# Altium schematic sheet power.SchDoc(power)
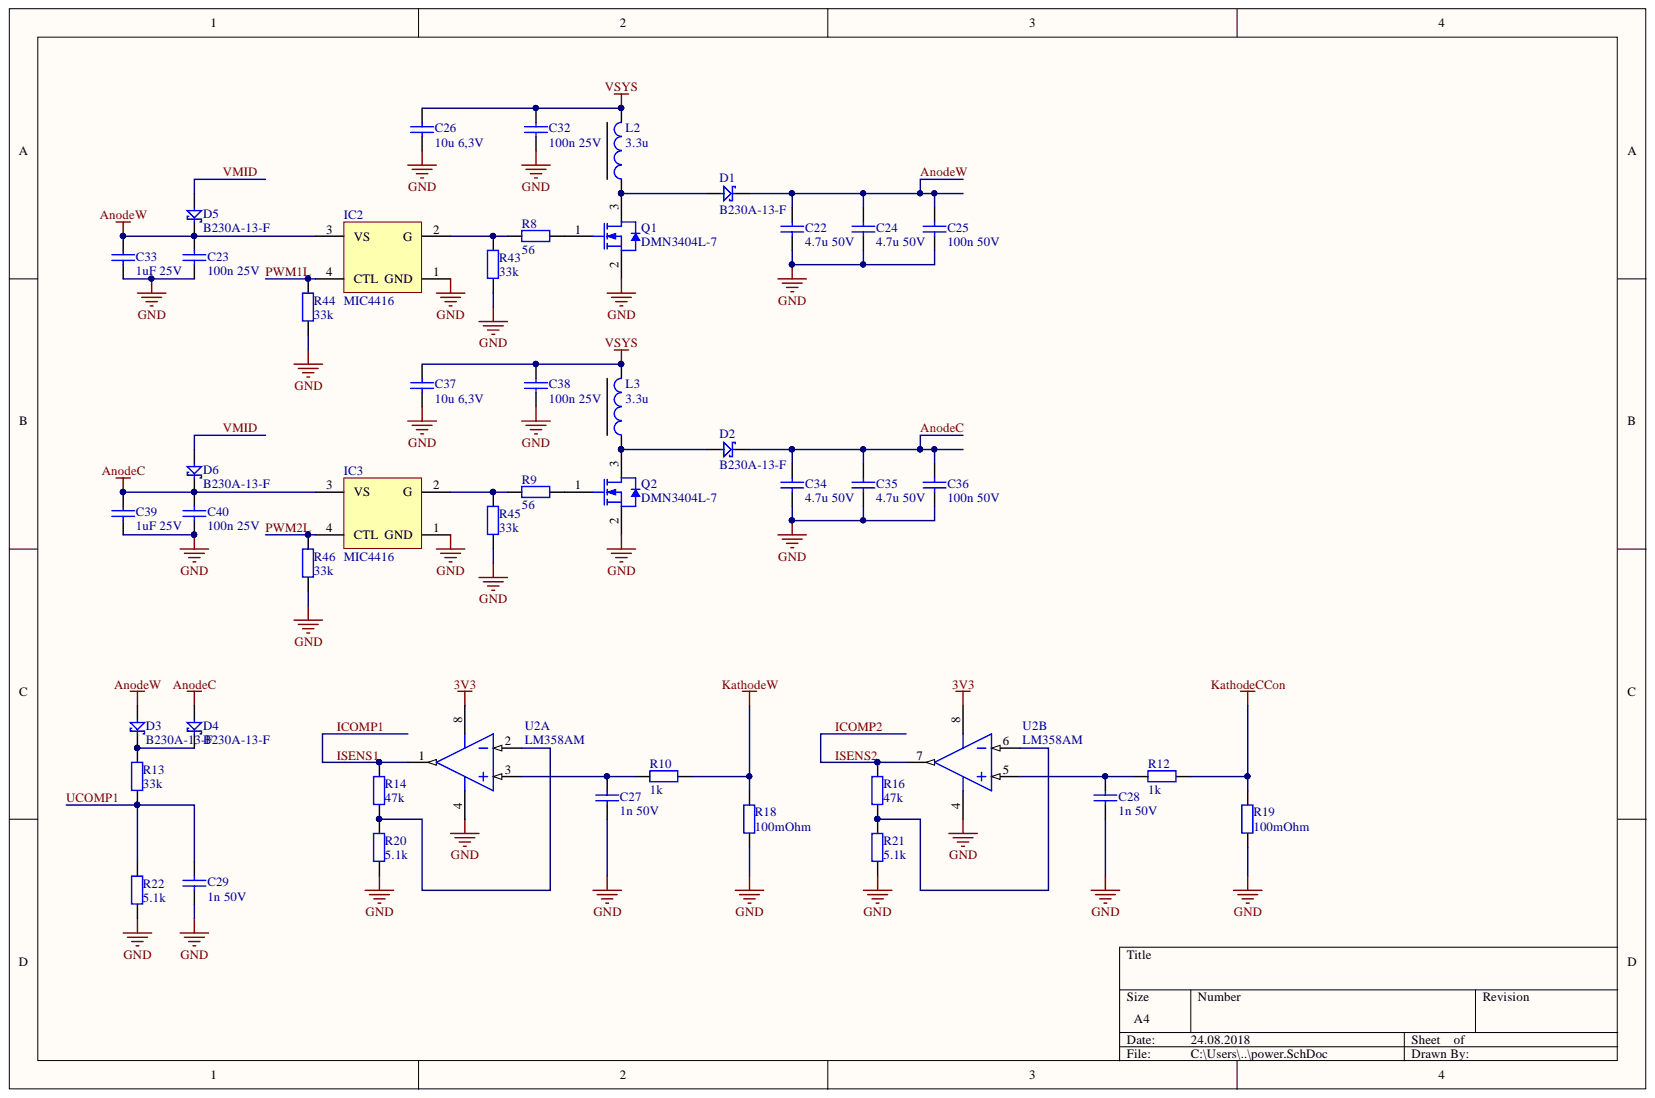
[diagram p0: power.SchDoc]

## Parts
C22  4.7u 50V  [C-1206-H]
C23  100n 25V  [C-0603-H]
C24  4.7u 50V  [C-1206-H]
C25  100n 50V  [C-0603-H]
C26  10u 6,3V  [C-0603-H]
C27  1n 50V  [C-0603-M]
C28  1n 50V  [C-0603-M]
C29  1n 50V  [C-0603-M]
C32  100n 25V  [C-0603-H]
C33  1uF 25V  [C-0603-H]
C34  4.7u 50V  [C-1206-H]
C35  4.7u 50V  [C-1206-H]
C36  100n 50V  [C-0603-H]
C37  10u 6,3V  [C-0603-H]
C38  100n 25V  [C-0603-H]
C39  1uF 25V  [C-0603-H]
C40  100n 25V  [C-0603-H]
D1  B230A-13-F  [DIODE-SMA]
D2  B230A-13-F  [DIODE-SMA]
D3  B230A-13-F  [DIODE-SMA]
D4  B230A-13-F  [DIODE-SMA]
D5  B230A-13-F  [DIODE-SMA]
D6  B230A-13-F  [DIODE-SMA]
IC2  MIC4416  [MIC4416]
IC3  MIC4416  [MIC4416]
L2  3.3u  [LPS4012]
L3  3.3u  [LPS4012]
Q1  DMN3404L-7  [DIOD-SOT23-3_V]
Q2  DMN3404L-7  [DIOD-SOT23-3_V]
R8  56  [R-0603-M]
R9  56  [R-0603-M]
R10  1k  [R-0603-M]
R12  1k  [R-0603-M]
R13  33k  [R-0603-H]
R14  47k  [R-0603-H]
R16  47k  [R-0603-H]
R18  100mOhm  [R-2512-M]
R19  100mOhm  [R-2512-M]
R20  5.1k  [R-0603-M]
R21  5.1k  [R-0603-M]
R22  5.1k  [R-0603-M]
R43  33k  [R-0603-H]
R44  33k  [R-0603-H]
R45  33k  [R-0603-H]
R46  33k  [R-0603-H]
U2  LM358AM  [D0008A_N]  (parts A,B)

## Nets
3V3: U2.8
GND: C22.1 C23.1 C24.1 C25.1 C26.1 C27.1 C28.1 C29.1 C32.1 C33.1 C34.1 C35.1 C36.1 C37.1 C38.1 C39.1 C40.1 IC2.1(GND) IC3.1(GND) Q1.2 Q2.2 R18.1 R19.1 R20.1 R21.1 R22.1 R43.1 R44.1 R45.1 R46.1 U2.4
KathodeCCon: R12.1 R19.2
KathodeW: R10.1 R18.2
VSYS: C26.2 C32.2 C37.2 C38.2 L2.2 L3.2
AnodeC: C34.2 C35.2 C36.2 C39.2 C40.2 D2.2 D4.1 D6.2 IC3.3(VS)
AnodeW: C22.2 C23.2 C24.2 C25.2 C33.2 D1.2 D3.1 D5.2 IC2.3(VS)
ICOMP1: R14.2 U2.1
ICOMP2: R16.2 U2.7
PWM1L: IC2.4(CTL) R44.2
PWM2L: IC3.4(CTL) R46.2
UCOMP1: C29.2 R13.1 R22.2
VMID: D5.1 D6.1
NetC27_2: C27.2 R10.2 U2.3
NetC28_2: C28.2 R12.2 U2.5
NetD1_1: D1.1 L2.1 Q1.3
NetD2_1: D2.1 L3.1 Q2.3
NetD3_2: D3.2 D4.2 R13.2
NetIC2_2: IC2.2(G) R8.1 R43.2
NetIC3_2: IC3.2(G) R9.1 R45.2
NetQ1_1: Q1.1 R8.2
NetQ2_1: Q2.1 R9.2
NetR14_1: R14.1 R20.2 U2.2
NetR16_1: R16.1 R21.2 U2.6

## Library data of the parts
C22 C24 C34 C35 = C-1206-4u7-50V: Capacitor 4.7uF 50V; Supplier Part Number 1: 81-GRM31CR71H475KA2L; Supplier 1: Mouser; Library Name: Capacitors-1206.SchLib; Component Kind: Standard
C23 C32 C38 C40 = C-0603-100n-25V: Capacitor 100n 25V; Supplier Part Number 1: 80-C0603C104K3R; Supplier 1: Mouser; Library Name: Capacitors-0603.SchLib; Component Kind: Standard
C25 C36 = C-0603-100n-50V: Capacitor 100n 50V; Supplier Part Number 1: 80-C0603C104K5RAUTO; Supplier 1: Mouser; Library Name: Capacitors-0603.SchLib; Component Kind: Standard
C26 C33 C37 C39 = C-0603-10u-6,3V: Capacitor 10uF 6,3V; Supplier Part Number 1: 80-C0603C106M9P; Supplier 1: Mouser; Library Name: Passives.IntLib; Component Kind: Standard
C27-C29 = C-0603-10n-50V: Capacitor 10nF 50V; Supplier Part Number 1: 80-C0603C103K5RAC; Supplier 1: Mouser; Library Name: Passives.IntLib; Component Kind: Standard
D1-D6 = D-B230A-13-F: Supplier Part Number 1: 621-B230A-F; Supplier 1: Mouser; Library Name: advanced.SchLib; Description: B230A-13-F; Component Kind: Standard
IC2 IC3 = MIC4416: MIC4416 Low Side Mosfet gate driver IttyBitty; Library Name: advanced.SchLib; Component Kind: Standard
L2 L3 = L-4u7-LPS4012-472MRB: LPS4012-472MRB; Supplier Part Number 1: 994-LPS4012-472MRB; Supplier 1: Mouser; Library Name: Inductors.SchLib; Component Kind: Standard
Q1 Q2 = CMP-2000-04929-1: N-Channel Enhancement Mode Field Effect Transistor, 60 V, 0.115 A, -55 to 150 degC, 3-Pin SOT23, RoHS, Tape and Reel; Manufacturer: DiodesIncorporated; Vgs - Gate-Source Voltage: 20V; Vds - Drain-Source Breakdown Voltage: 70V; Unit Weight: 0.000282oz; Typical Turn-On Delay Time: 7ns; Typical Turn-Off Delay Time: 11ns; Transistor Type: 1N-Channel; Rise Time: 3ns; Rds On - Drain-Source Resistance: 7.5Ohms; Pd - Power Dissipation: 300mW; Number of Channels: 1Channel; Id - Continuous Drain Current: 115mA; Forward Transconductance - Min: 0.08S; Fall Time: 5.6ns; Configuration: Single; Width: 1.3mm; Type: EnhancementModeFieldEffectTransistor; Transistor Polarity: N-Channel; Technology: Si; Series: 2N7002; RoHS: True; Product Category: MOSFET; Product: MOSFETSmallSignal; Packaging: Reel; Package Reference: SOT23-3; Package Description: 3-Pin Small Outline Transistor, Body 2.9 x 1.3 mm, Pitch 0.96 mm; Package / Case: SOT-23-3; Mounting Style: SMD/SMT; Minimum Operating Temperature: -55C; Maximum Operating Temperature: +150C; Manufacturer URL: http://www.diodes.com/; Library Name: Altium Content Vault; Length: 2.9mm; Height: 1mm; Factory Pack Quantity: 3000; Datasheet Version: Rev. 21-2, 02/2009; Datasheet URL: http://datasheet.octopart.com/2N7002-7-F-Diodes-Inc.-datasheet-8326113.pdf; Component Kind: Standard; Channel Mode: Enhancement; Brand: DiodesIncorporated
R8 R9 = R-0603-22: Resistor 22; Supplier Part Number 1: 71-CRCW0603-22-E3; Supplier 1: Mouser; Library Name: Resistors-0603.SchLib; Component Kind: Standard
R10 R12 = R-0603-1k: Resistor 1k; Supplier Part Number 1: 71-CRCW0603-1.0K-E3; Supplier 1: Mouser; Library Name: Passives.IntLib; Component Kind: Standard
R13 R14 R16 R43-R46 = R-0603-47k: Resistor 4k7; Supplier Part Number 1: 71-CRCW060347K0FKEB; Supplier 1: Mouser; Library Name: Passives.IntLib; Component Kind: Standard
R18 R19 = R-2512-0.1: Resistor 0.1; Supplier 1: Mouser; Component Kind: Standard; Library Name: erleuchtung.IntLib
R20-R22 = R-0603-5k1: Resistor 5.1k; Supplier Part Number 1: 71-CRCW0603-5.1K-E3; Supplier 1: Mouser; Library Name: Passives.IntLib; Component Kind: Standard
U2 = CMP-0055-00796-3: Low Power Dual Operational Amplifier, 8-pin Narrow SOIC; Manufacturer: Texas Instruments; PowerWise: N; PackageTopMarking: NSZXTT*LM*358AM; CompType: Amplifiers; RoHS: N; PinCount: 8; PartId: 1; Package Reference: D0008A; PackageDescription: SOIC Narrow, 4.9 x 3.9 x 1.45mm, 8 Lead, 1.27mm Pitch; Library Name: Altium Content Vault; ComponentLink1URL: http://www.ti.com/general/docs/lit/getliterature.tsp?genericPartNumber=LM358-N&fileType=pdf; ComponentLink1Description: Datasheet URL; Component Kind: Standard; Code_JEDEC: MS-012-AA; Code_IPC: SOIC127P600-8
